# Altium schematic sheet DAC.SchDoc(DAC)
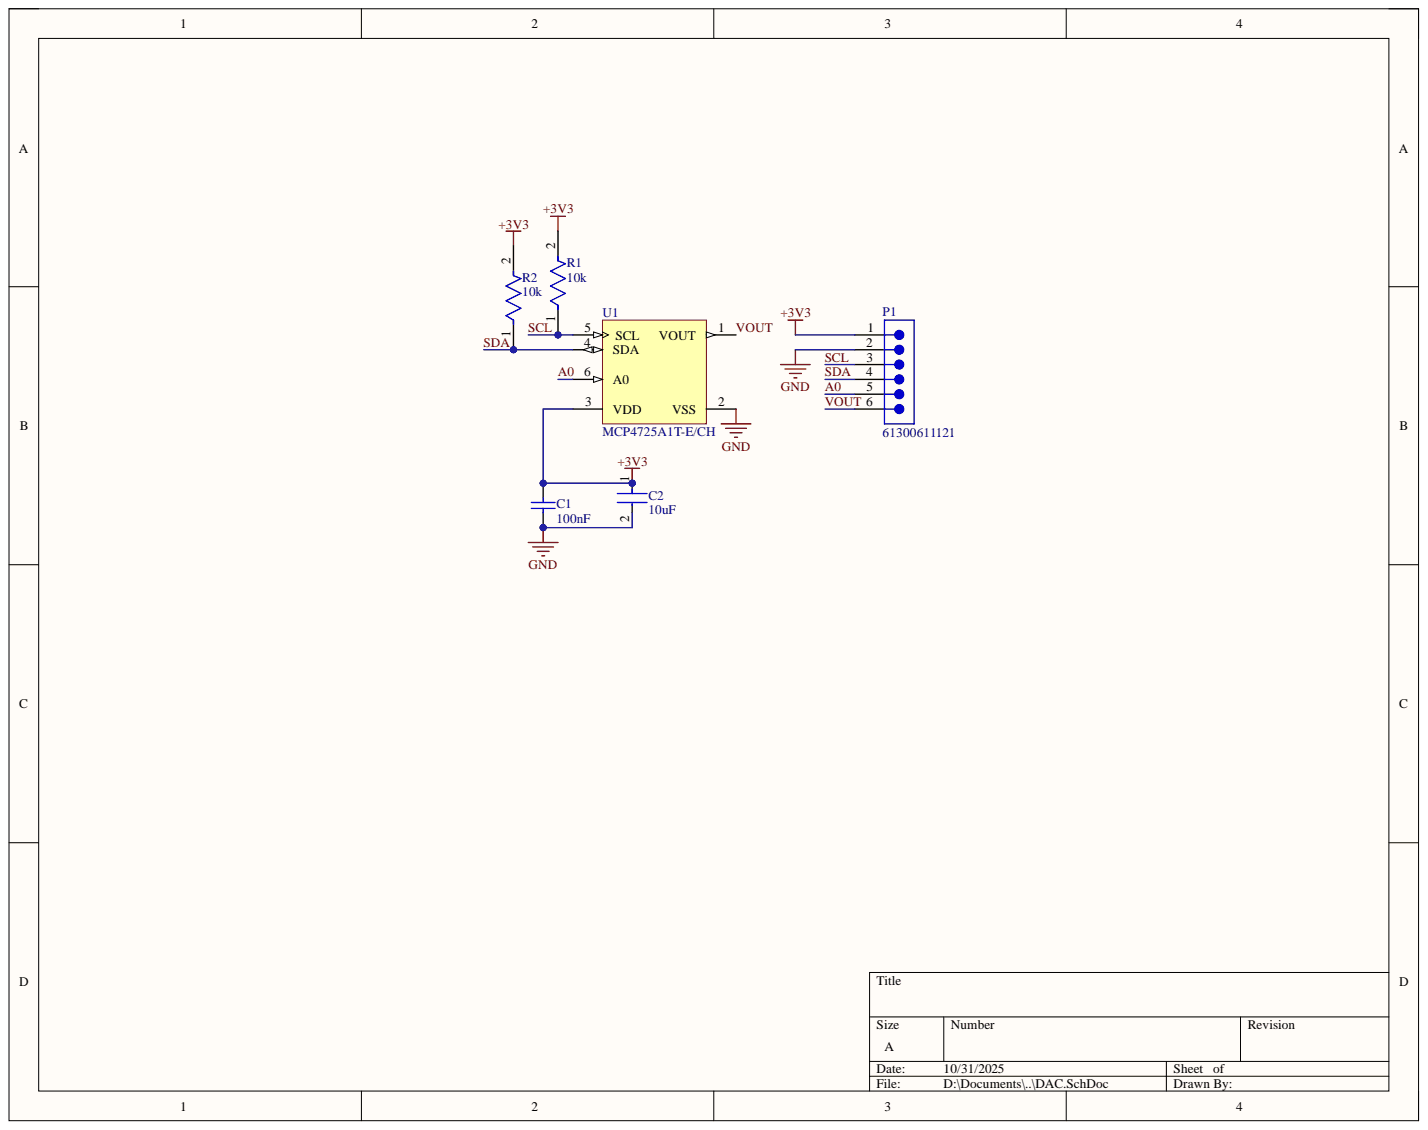
[diagram p0: DAC.SchDoc]

## Parts
C1  100nF  [CAPC1050X55X23ML05T13]
C2  10uF  [FP-C0805C106J8RACAUTO-MFG]
P1  61300611121  [61300611121]
R1  10k  [FP-CRCW0402-C-e3-IPC_C]
R2  10k  [FP-CRCW0402-C-e3-IPC_C]
U1  MCP4725A1T-E/CH  [SOT-23-CH6_N]

## Nets
+3V3: C1.2 C2.1 P1.1 R1.2 R2.2 U1.3(VDD)
GND: C1.1 C2.2 P1.2 U1.2(VSS)
A0: P1.5 U1.6(A0)
SCL: P1.3 R1.1 U1.5(SCL)
SDA: P1.4 R2.1 U1.4(SDA)
VOUT: P1.6 U1.1(VOUT)

## Library data of the parts
C1 = CMP-2000-05439-1: Chip Capacitor, 100 nF, +/- 10%, 16 V, -55 to 125 degC, 0402 (1005 Metric), RoHS, Tape and Reel; Manufacturer: Samsung Electro-Mechanics; Capacitance: 100000pF; Dielectric: X7R; Rated Voltage: 16V; Case Size/Dimension: 0402; Component Kind: Standard; Datasheet URL: https://datasheet.ciiva.com/29198/458718-da-01-en-vs-kondensator-0402-29198423.pdf; Datasheet Version: Nov-10; Library Name: Altium Content Vault; Manufacturer URL: http://www.samsungsem.com/global/index.jsp; Tolerance (%): ±10%
C2 = CMP-03020-034708-1: CAP CER 10UF 10V X7R 0805; Tolerance: 5%; Capacitance: 10uF; Case Code (Imperial): 0805; Case Code (Metric): 2012; Case/Package: 0805; Component Kind: Standard; Library Name: Altium Content Vault; Max Operating Temperature: 125°C; Min Operating Temperature: -55°C; Mount: Surface Mount; Packaging: Tape and Reel; Ratings: AEC-Q200; RoHS Compliant: Yes; Voltage Rating: 10V
P1 = CMP-1502-01070-3: NexarPartId: 20084590; Connector Type: Male Pin; Contact Resistance: 20mΩ; Current Rating: 3A; Gender: Male; Number of Contacts: 6; Operating Supply Voltage: 250V; Pitch: 2.54mm; Color: Black; Component Kind: Standard; Contact Gender: Male; Contact Material: Copper; Contact Mating Length: 5.9944mm; Contact Plating: Gold; Height: 8.89mm; Housing Material: PBT; Lead Free: Lead Free; Lead Pitch: 2.54mm; Library Name: Altium Content Vault; Max Operating Temperature: 105°C; Min Operating Temperature: -40°C; Mount: Through Hole; Number of Pins: 6; Number of Positions: 6; Number of Rows: 1; Orientation: Vertical; Packaging: Bulk; REACH SVHC: Unknown; RoHS: Compliant; Termination: Through Hole; Voltage Rating: 250V
R1 R2 = CMP-02407-012085-1: RES 10K OHM 1% 1/16W 0402; Power Rating: 62.5mW; Resistance: 10kR; Temperature Coefficient: 100ppm/°C; Case/Package: Molded; Component Kind: Standard; Contact Plating: Tin; Lead Free: Lead Free; Length: 1mm; Library Name: Altium Content Vault; Max Operating Temperature: 155°C; Min Operating Temperature: -55°C; Mount: Surface Mount; Resistor Type: Thick Film; RoHS Compliant: Yes; Termination: Wraparound; Tolerance: 1%
U1 = CMP-0188-00759-2: 12-Bit Digital-to-Analog Converter with EEPROM Memory in SOT-23-6, 6-Pin SOT-23, Extended Temperature, Tape and Reel; Code_IPC: SOT26A-6; Component Kind: Standard; ComponentLink1Description: Manufacturer URL; ComponentLink1URL: http://www.microchip.com/; ComponentLink2Description: Datasheet; ComponentLink2URL: http://ww1.microchip.com/downloads/en/DeviceDoc/22039d.pdf; ComponentLink3Description: Package Specification; ComponentLink3URL: http://www.microchip.com/stellent/groups/techpub_sg/documents/packagingspec/en012702.pdf; DatasheetVersion: revD, Jun-2009; Library Name: Altium Content Vault; PackageDescription: 6-Lead Plastic Small Outline Transistor (CH) [SOT-23]; PackageReference: SOT-23-CH6; PackageVersion: revBB, Aug-2009; RevisionNote: URLs added.
